# Altium schematic sheet 102-002-00138-00,K12燃气传感器V1.0原理图.SchDoc
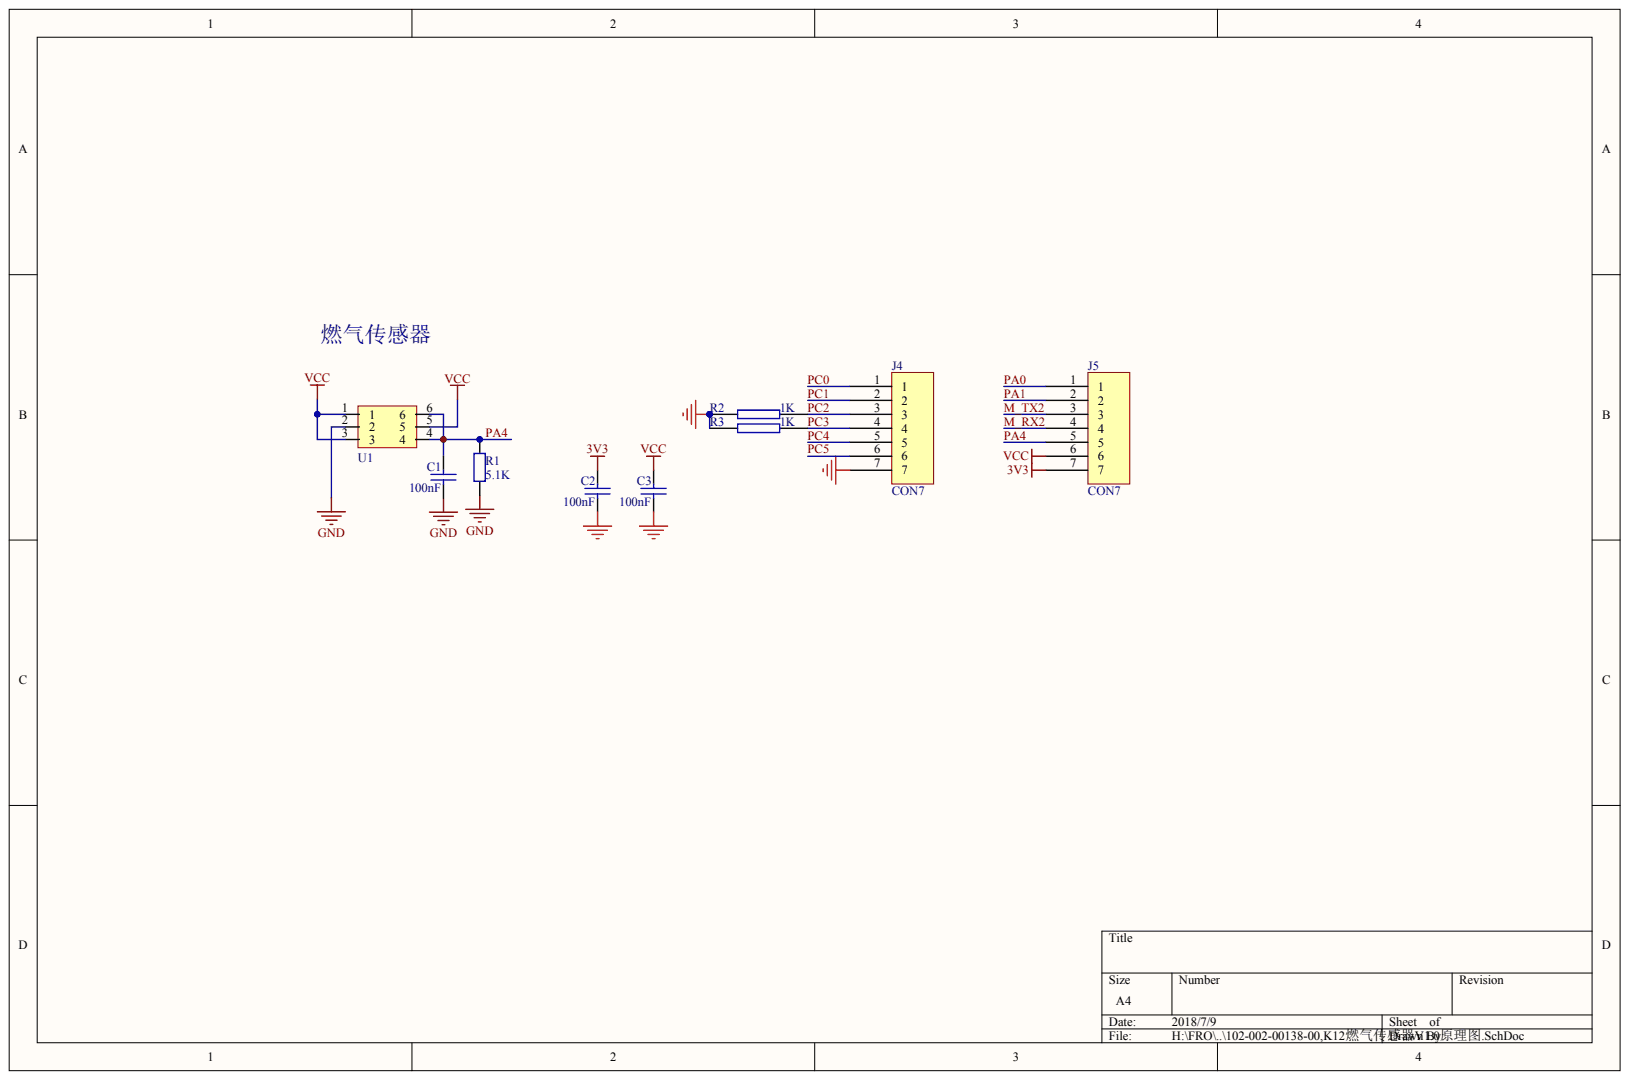
[diagram p0: 102-002-00138-00,K12燃气传感器V1.0原理图.SchDoc]

## Parts
C1  100nF
C2  100nF
C3  100nF
J4  CON7
J5  CON7
R1  5.1K
R2  1K
R3  1K
U1  MQ-2/3  (value not drawn)

## Nets
3V3: C2.2 J5.7
GND: C1.1 C2.1 C3.1 J4.7 R1.2 R2.1 R3.1 U1.2
VCC: C3.2 J5.6 U1.1 U1.3 U1.5
M_RX2: J5.4
M_TX2: J5.3
PA0: J5.1
PA1: J5.2
PA4: C1.2 J5.5 R1.1 U1.4 U1.6
PC0: J4.1
PC1: J4.2
PC2: J4.3 R2.2
PC3: J4.4 R3.2
PC4: J4.5
PC5: J4.6

## Library data of the parts
C1-C3 = (no symbol name): wuliaobianma: 104-001-00104-50; wuliaoguige: 0805/100nF/50V/X7R/J; wuliaomingchen: 贴片无极电容
R1 = (no symbol name): wuliaoguige: 0805/5.1kΩ/F; wuliaobianma: 103-001-00512-01; wuliaomingchen: 贴片电阻
R2 R3 = (no symbol name): wuliaobianma: 103-001-00102-01; wuliaoguige: 0805/1KΩ/F; wuliaomingchen: 贴片电阻
U1 = (no symbol name): wuliaoguige: MQ-5; wuliaomingchen: 燃气传感器; wuliaobianma: 199-008-000XX-00
